# Altium schematic sheet IO_Headers.SchDoc(IO_Headers)
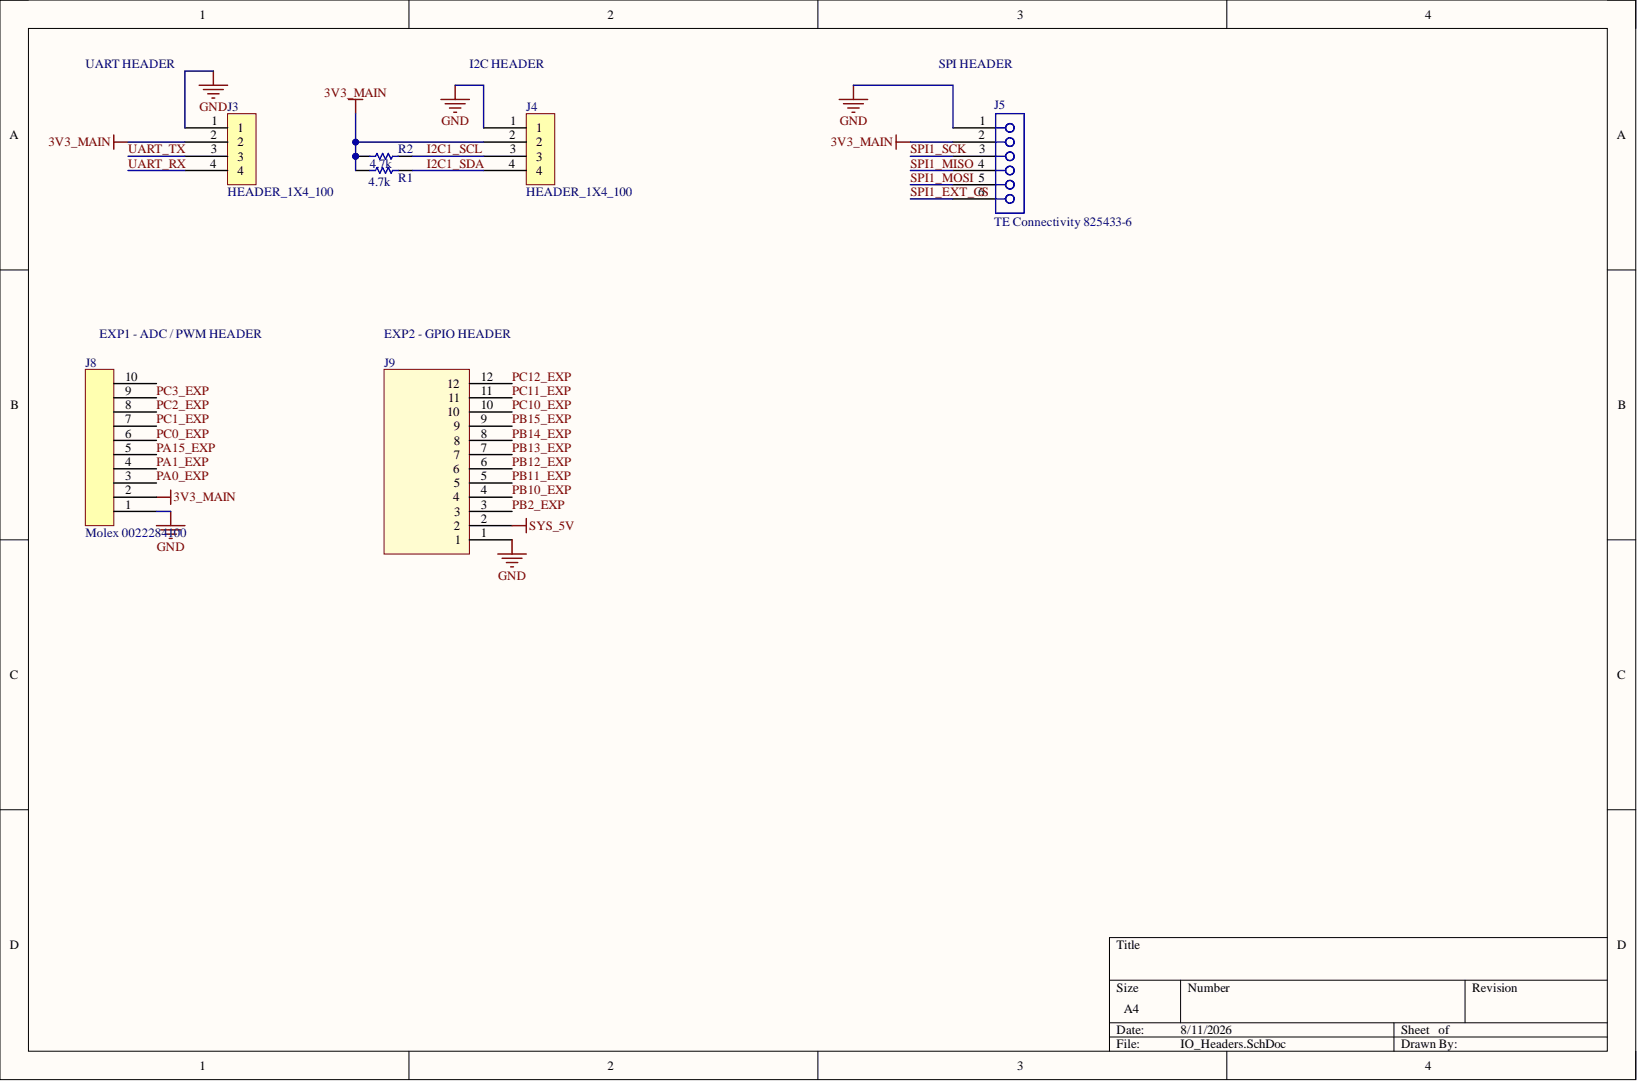
[diagram p0: IO_Headers.SchDoc]

## Parts
J3  HEADER_1X4_100  (no BOM)
J4  HEADER_1X4_100  (no BOM)
J5  TE Connectivity 825433-6
J8  Molex 0022284100  Manufacturer Part Number: 0022284100  [PCB-ee3wr2aq0bv45et754xw-1]
J9  Samtec TSM-112-01-L-SV  (value not drawn)
R1  4.7k  [PCB-0002-00066-3]
R2  4.7k  [PCB-0002-00066-3]

## Nets
3V3_MAIN: J3.2 J4.2 J5.2 J8.2 R1.1 R2.1
GND: J3.1 J4.1 J5.1 J8.1 J9.1
SYS_5V: J9.2
I2C1_SCL: J4.3 R2.2
I2C1_SDA: J4.4 R1.2
PA0_EXP: J8.3
PA1_EXP: J8.4
PA15_EXP: J8.5
PB2_EXP: J9.3
PB10_EXP: J9.4
PB11_EXP: J9.5
PB12_EXP: J9.6
PB13_EXP: J9.7
PB14_EXP: J9.8
PB15_EXP: J9.9
PC0_EXP: J8.6
PC1_EXP: J8.7
PC2_EXP: J8.8
PC3_EXP: J8.9
PC10_EXP: J9.10
PC11_EXP: J9.11
PC12_EXP: J9.12
SPI1_EXT_CS: J5.6
SPI1_MISO: J5.4
SPI1_MOSI: J5.5
SPI1_SCK: J5.3
UART_RX: J3.4
UART_TX: J3.3

Not connected: J8 10

## Library data of the parts
J3 J4 = CMP-64ffaf704303bb38-20: Conn Unshrouded Header HDR 4 POS 2.54mm Solder ST Thru-Hole; Library Name: My Personal Space
J5 = CMP-88ed4362af17572a-9: Conn Unshrouded Header HDR 6 POS 2.54mm Solder ST Thru-Hole - No Outline; Current Rating: 3.0 A; ELV Compliant: Compliant; Gender: Male; Number of Contacts: 6; Pin Pitch: 0.00254 m; Component Kind: Standard; Contact Material: Brass; Contact Plating: Gold, Gold over Nickel, Tin, Tin over Nickel; Flammability Rating: UL 94 V-0; Housing Color: Green; Housing Material: Polyester; Library Name: My Personal Space; Lifecycle Status: Active; Manufacturer Part Number: 825433-6; Mounting Style: PCB; Octopart URL: http://octopart.com/825433-6-te+connectivity-42271388; OctopartID: 88ed4362af17572a; Orientation: Vertical; Packaging: Box; REACH SVHC Compliance: No SVHC; RoHS: Compliant
J8 = CMP-4d308488f3b592f7-3: 2.54mm (.100") Pitch KK® Header, Breakaway, Vertical, 10 Circuits, Tin (Sn) Plating, Mating Pin Length 6.09mm (.240"); Gender: Male; Component Kind: Standard; Library Name: My Personal Space; Lifecycle Status: Not Listed by Manufacturer; Mounting Style: Solder; Octopart URL: https://octopart.com/0022284100-molex-11705315; OctopartID: 4d308488f3b592f7; RoHS: Compliant
J9 = CMP-86a14eaf92625966-1: "This surface mount .025” square post terminal strip features single; Gender: Male; Lead-Free Status: Lead Free; Number of Contacts: 12; Component Kind: Standard; Contact Material: Phosphor Bronze; Contact Plating: Gold; Library Name: My Personal Space; Manufacturer Part Number: TSM-112-01-L-SV; Mounting Style: Surface Mount, Vertical; Number of Rows: 1; Octopart Url: https://octopart.com/tsm-112-01-l-sv-samtec-17052069; OctopartID: 86a14eaf92625966; Packaging: Tube; REACH SVHC Compliance: No SVHC; RoHS: Compliant
R1 R2 = CMP-e2bf28f8a65d3d78-15: Res Thick Film 0603 4.7K Ohm 1% 1/10W ±100ppm/°C Molded SMD Paper T/R; Manufacturer Part Number: RC0603FR-074K7L; Case/Package (SI): 1608; Lead-Free Status: Lead Free; Power Rating: 100 mW; Resistance: 4.70 kΩ; Temperature Coefficient: -100 ppm/°C to 100 ppm/°C; Voltage Rating (DC): 75.0 V, 50.0 V; Case/Package: 0603; Component Kind: Standard; Library Name: My Personal Space; Mounting Style: Surface Mount; Number of Pins: 2; Octopart URL: https://octopart.com/rc0603fr-074k7l-yageo-55402884; OctopartID: e2bf28f8a65d3d78; Operating Temperature: 155 °C (max); Packaging: Tape & Reel; REACH SVHC Compliance: No SVHC; Resistance Tolerance: ±1%; RoHS: Compliant; Size-Height: 450 µm; Size-Length: 1.60 mm; Size-Width: 800 µm
